# Altium schematic sheet 主板.SchDoc
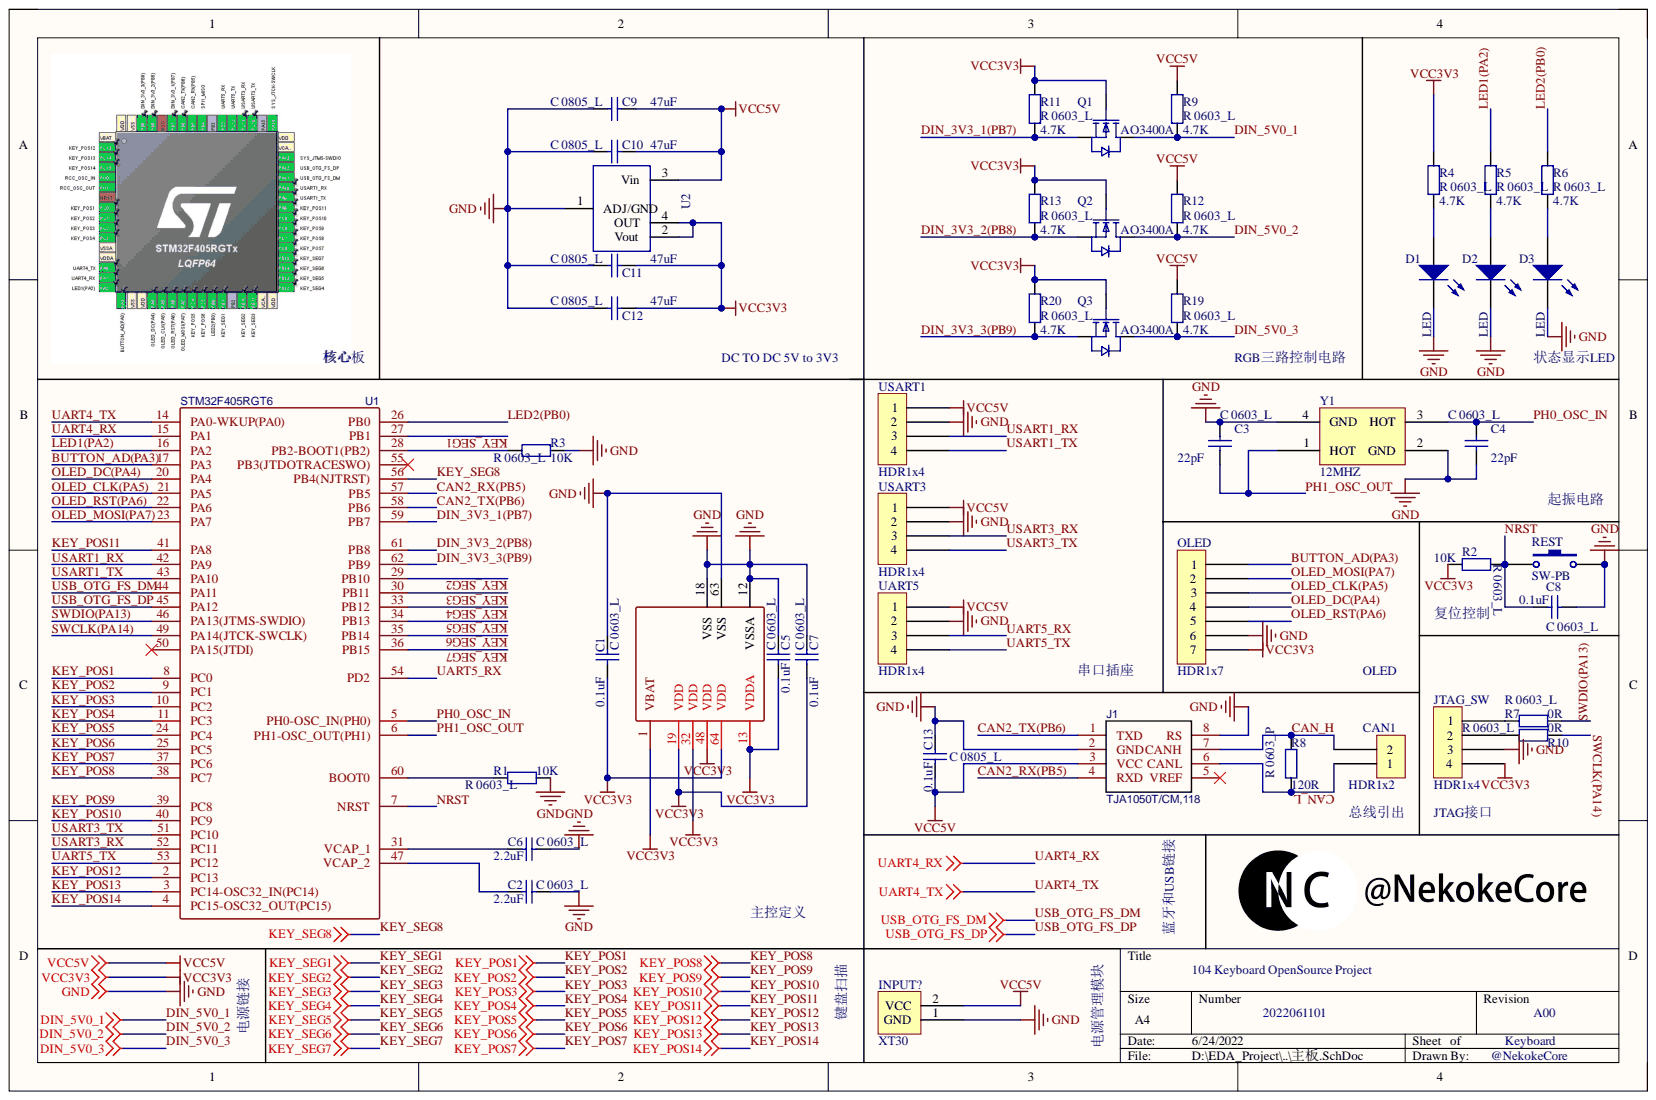
[diagram p0: 主板.SchDoc]

## Parts
C1  C 0603_L  value 0.1uF  [C 0603_L]
C2  C 0603_L  value 2.2uF  [C 0603_L]
C3  C 0603_L  value 22pF  [C 0603_L]
C4  C 0603_L  value 22pF  [C 0603_L]
C5  C 0603_L  value 0.1uF  [C 0603_L]
C6  C 0603_L  value 2.2uF  [C 0603_L]
C7  C 0603_L  value 0.1uF  [C 0603_L]
C8  C 0603_L  value 0.1uF  [C 0603_L]
C9  C 0805_L  value 47uF  [C 0805_L]
C10  C 0805_L  value 47uF  [C 0805_L]
C11  C 0805_L  value 47uF  [C 0805_L]
C12  C 0805_L  value 47uF  [C 0805_L]
C13  C 0805_L  value 0.1uF  [C 0805_L]
CAN1  HDR1x2  [GH1.25-立贴-2P]
D1  LED  [LED 0805R]
D2  LED  [LED 0805R]
D3  LED  [LED 0805R]
INPUT?  XT30  [XT30PW-M]
J1  TJA1050T/CM,118  [SOIC-8_150MIL]
JTAG_SW  HDR1x4  [GH1.25-立贴-4P]
OLED  HDR1x7  [GH1.25-立贴-7P]
Q1  AO3400A  [AO3400A]
Q2  AO3400A  [AO3400A]
Q3  AO3400A  [AO3400A]
R1  R 0603_L  value 10K  [R 0603_L]
R2  R 0603_L  value 10K  [R 0603_L]
R3  R 0603_L  value 10K  [R 0603_L]
R4  R 0603_L  value 4.7K  [R 0603_L]
R5  R 0603_L  value 4.7K  [R 0603_L]
R6  R 0603_L  value 4.7K  [R 0603_L]
R7  R 0603_L  value 0R  [R 0603_L]
R8  R 0603_P  value 120R  [0603P]
R9  R 0603_L  value 4.7K  [R 0603_L]
R10  R 0603_L  value 0R  [R 0603_L]
R11  R 0603_L  value 4.7K  [R 0603_L]
R12  R 0603_L  value 4.7K  [R 0603_L]
R13  R 0603_L  value 4.7K  [R 0603_L]
R19  R 0603_L  value 4.7K  [R 0603_L]
R20  R 0603_L  value 4.7K  [R 0603_L]
REST  SW-PB  [SMD-3.2*4.2]
U1  STM32F405RGT6  [STM32F405RGT6]
U2  SPX1117M3-L-3-3  [SPX1117M3-L-3-3/TR]  (value not drawn)
UART5  HDR1x4  [GH1.25-立贴-4P]
USART1  HDR1x4  [GH1.25-立贴-4P]
USART3  HDR1x4  [GH1.25-立贴-4P]
Y1  12MHZ  [SMD-3225_4P]

## Nets
GND: C1.2 C2.2 C3.2 C4.1 C5.2 C6.2 C7.2 C8.2 C9.1 C10.1 C11.1 C12.1 C13.2 D1.2 D2.2 D3.2 INPUT?.1 J1.2(GND) J1.8(RS) JTAG_SW.3 OLED.6 R1.2 R3.2 REST.2 U1.12(VSSA) U1.18(VSS) U1.63(VSS) U2.1(ADJ/GND) UART5.2 USART1.2 USART3.2 Y1.2(GND) Y1.4(GND)
VCC3V3: C1.1 C5.1 C7.1 C11.2 C12.2 JTAG_SW.4 OLED.7 Q1.1 Q2.1 Q3.1 R2.1 R4.2 R11.2 R13.2 R20.2 U1.1(VBAT) U1.13(VDDA) U1.19(VDD) U1.32(VDD) U1.48(VDD) U1.64(VDD) U2.2(Vout) U2.4(OUT)
VCC5V: C9.2 C10.2 C13.1 INPUT?.2 J1.3(VCC) R9.2 R12.2 R19.2 U2.3(Vin) UART5.1 USART1.1 USART3.1
BUTTON_AD(PA3): OLED.1 U1.17(PA3)
CAN2_RX(PB5): J1.4(RXD) U1.57
CAN2_TX(PB6): J1.1(TXD) U1.58
CAN_H: CAN1.2 J1.7(CANH) R8.2
CAN_L: CAN1.1 J1.6(CANL) R8.1
DIN_3V3_1(PB7): Q1.2 R11.1 U1.59
DIN_3V3_2(PB8): Q2.2 R13.1 U1.61
DIN_3V3_3(PB9): Q3.2 R20.1 U1.62
DIN_5V0_1: Q1.3 R9.1
DIN_5V0_2: Q2.3 R12.1
DIN_5V0_3: Q3.3 R19.1
KEY_POS1: U1.8(PC0)
KEY_POS2: U1.9(PC1)
KEY_POS3: U1.10(PC2)
KEY_POS4: U1.11(PC3)
KEY_POS5: U1.24(PC4)
KEY_POS6: U1.25(PC5)
KEY_POS7: U1.37(PC6)
KEY_POS8: U1.38(PC7)
KEY_POS9: U1.39(PC8)
KEY_POS10: U1.40(PC9)
KEY_POS11: U1.41(PA8)
KEY_POS12: U1.2(PC13)
KEY_POS13: U1.3(PC14-OSC32_IN(PC14))
KEY_POS14: U1.4(PC15-OSC32_OUT(PC15))
KEY_SEG1: U1.27
KEY_SEG2: U1.29
KEY_SEG3: U1.30
KEY_SEG4: U1.33
KEY_SEG5: U1.34
KEY_SEG6: U1.35
KEY_SEG7: U1.36
KEY_SEG8: U1.56
LED1(PA2): R5.2 U1.16(PA2)
LED2(PB0): R6.2 U1.26
NRST: C8.1 R2.2 REST.1 U1.7
OLED_CLK(PA5): OLED.3 U1.21(PA5)
OLED_DC(PA4): OLED.4 U1.20(PA4)
OLED_MOSI(PA7): OLED.2 U1.23(PA7)
OLED_RST(PA6): OLED.5 U1.22(PA6)
PH0_OSC_IN: C4.2 U1.5 Y1.3(HOT)
PH1_OSC_OUT: C3.1 U1.6 Y1.1(HOT)
SWCLK(PA14): R10.2 U1.49(PA14(JTCK-SWCLK))
SWDIO(PA13): R7.2 U1.46(PA13(JTMS-SWDIO))
UART4_RX: U1.15(PA1)
UART4_TX: U1.14(PA0-WKUP(PA0))
UART5_RX: U1.54 UART5.3
UART5_TX: U1.53(PC12) UART5.4
USART1_RX: U1.42(PA9) USART1.3
USART1_TX: U1.43(PA10) USART1.4
USART3_RX: U1.52(PC11) USART3.3
USART3_TX: U1.51(PC10) USART3.4
USB_OTG_FS_DM: U1.44(PA11)
USB_OTG_FS_DP: U1.45(PA12)
NetC2_1: C2.1 U1.47
NetC6_1: C6.1 U1.31
NetD1_1: D1.1 R4.1
NetD2_1: D2.1 R5.1
NetD3_1: D3.1 R6.1
NetJTAG_SW_1: JTAG_SW.1 R7.1
NetJTAG_SW_2: JTAG_SW.2 R10.1
NetR1_1: R1.1 U1.60
NetR3_1: R3.1 U1.28

Not connected: J1 5(VREF); U1 50(PA15(JTDI)) 55

## Library data of the parts
C1-C8 = C 0603_L: Capacitor; Component Kind: Standard; LatestRevisionDate: 17-Jul-2002; LatestRevisionNote: Re-released for DXP Platform.; Library Name: Schlib1.SchLib; PackageDescription: Radial Cap, Thru-Hole; 2 Leads; 0.3 in Pin Spacing; PackageReference: RAD-0.3; Published: 8-Jun-2000; Publisher: Altium Limited
C9 C10 C12 C13 = C 0805_L: Capacitor; Component Kind: Standard; LatestRevisionDate: 17-Jul-2002; LatestRevisionNote: Re-released for DXP Platform.; Library Name: Schlib1.SchLib; PackageDescription: Radial Cap, Thru-Hole; 2 Leads; 0.3 in Pin Spacing; PackageReference: RAD-0.3; Published: 8-Jun-2000; Publisher: Altium Limited
C11 = C 0805_L: Capacitor; Value: 47uF; Component Kind: Standard; LatestRevisionDate: 17-Jul-2002; LatestRevisionNote: Re-released for DXP Platform.; Library Name: Schlib1.SchLib; PackageDescription: Radial Cap, Thru-Hole; 2 Leads; 0.3 in Pin Spacing; PackageReference: RAD-0.3; Published: 8-Jun-2000; Publisher: Altium Limited
D1-D3 = LED 0805R 红: Typical INFRARED GaAs LED; Component Kind: Standard; LatestRevisionDate: 08-Jul-2005; LatestRevisionNote: Mech Layer 1 removed.; Library Name: Schlib1.SchLib; PackageDescription: LED; 2 Leads; PackageReference: LED-0; Published: 8-Jun-2000; Publisher: Altium Limited
J1 = TJA1050T/CM,118: BOM_Manufacturer: NXP; BOM_JLCPCB Part Class: Basic Part; BOM_Manufacturer Part: TJA1050T/CM,118; BOM_Supplier: LCSC; BOM_Supplier Part: C6952; Component Kind: Standard; Library Name: Schlib1.SchLib; spicePre: U; spiceSymbolName: TJA1050T/CM,118
JTAG_SW UART5 USART1 USART3 = HDR1x4_卧: Component Kind: Standard; Library Name: Schlib1.SchLib
Q1-Q3 = 2N7002,215: Manufacturer: Nexperia(安世); Add into BOM: yes; Continuous Drain Current (Id): 300mA; Drain Source On Resistance (RDS(on)@Vgs,Id): 5Ω@10V,500mA; Drain Source Voltage (Vdss): 60V; Gate Threshold Voltage (Vgs(th)@Id): 2.5V@250A; Power Dissipation (Pd): 830mW; Type: N沟道; Component Kind: Standard; Convert to PCB: yes; Datasheet: https://atta.szlcsc.com/upload/public/pdf/source/20170103/1483439882638.pdf; Device: 2N7002,215; JLCPCB Part Class: Extended Part; LCSC Part Name: N沟道 60V 300mA; Library Name: Schlib1.SchLib; Manufacturer Part: 2N7002,215; Supplier: LCSC; Supplier Footprint: SOT-23(SOT-23-3); Supplier Part: C65189; Symbol: 2N7002,215
R1-R7 R9-R13 R19 R20 = R 0603_L: Resistor; Component Kind: Standard; LatestRevisionDate: 17-Jul-2002; LatestRevisionNote: Re-released for DXP Platform.; Library Name: Schlib1.SchLib; PackageDescription: Axial Device, Thru-Hole; 2 Leads; 0.4 in Pin Spacing; PackageReference: AXIAL-0.4; Published: 8-Jun-2000; Publisher: Altium Limited
R8 = R 0603_P: Resistor; Component Kind: Standard; LatestRevisionDate: 17-Jul-2002; LatestRevisionNote: Re-released for DXP Platform.; Library Name: Schlib1.SchLib; PackageDescription: Axial Device, Thru-Hole; 2 Leads; 0.4 in Pin Spacing; PackageReference: AXIAL-0.4; Published: 8-Jun-2000; Publisher: Altium Limited
REST = SW-PB: Switch; Component Kind: Standard; LatestRevisionDate: 17-Jul-2002; LatestRevisionNote: Re-released for DXP Platform.; Library Name: Schlib1.SchLib; PackageDescription: Switch; 2 Leads; PackageReference: SPST-2; Published: 24-Mar-1999; Publisher: Altium Limited
U1 = STM32F405RGT6: BOM_Manufacturer: ST; subpart_no: 1; tags: STM32; BOM_JLCPCB Part Class: Extended Part; BOM_Manufacturer Part: STM32F405RGT6; BOM_Supplier: LCSC; BOM_Supplier Part: C15742; Component Kind: Standard; image: http://image.lceda.cn/szlcsc/C15742.jpg; Library Name: Schlib1.SchLib; spicePre: U; spiceSymbolName: STM32F405RGT6
U2 = SPX1117M3-L-3-3: Manufacturer: UTC(友顺); Add into BOM: yes; Device: LM317G-AA3-R; Dropout Voltage: 5V@(10mA); Maximum Input Voltage: 40V; Number of Outputs: 1; Output Current: 1A; Output Polarity: 正; Output Type: 可调; Output Voltage: 1.3V~37V; Component Kind: Standard; Convert to PCB: yes; Datasheet: https://atta.szlcsc.com/upload/public/pdf/source/20160416/1460799387250.pdf; JLCPCB Part Class: Extended Part; LCSC Part Name: Vin=40V Vout=1.3V~37V 1A; Library Name: Schlib1.SchLib; Manufacturer Part: LM317G-AA3-R; Supplier: LCSC; Supplier Footprint: SOT-223; Supplier Part: C19651; Symbol: LM317G-AA3-R
Y1 = 3325 12Mhz: 3325 12MHZ 无源晶振; Component Kind: Standard; Library Name: Schlib1.SchLib
CAN1 = HDR1x2: Component Kind: Standard; Library Name: Schlib1.SchLib
INPUT? = XT30: Component Kind: Standard; Library Name: Schlib1.SchLib
OLED = HDR1x7: Component Kind: Standard; Library Name: Schlib1.SchLib
